# Altium schematic sheet Sch-QWA17_V7_ImageSensor.SchDoc(Sch-QWA17_V7_ImageSensor)
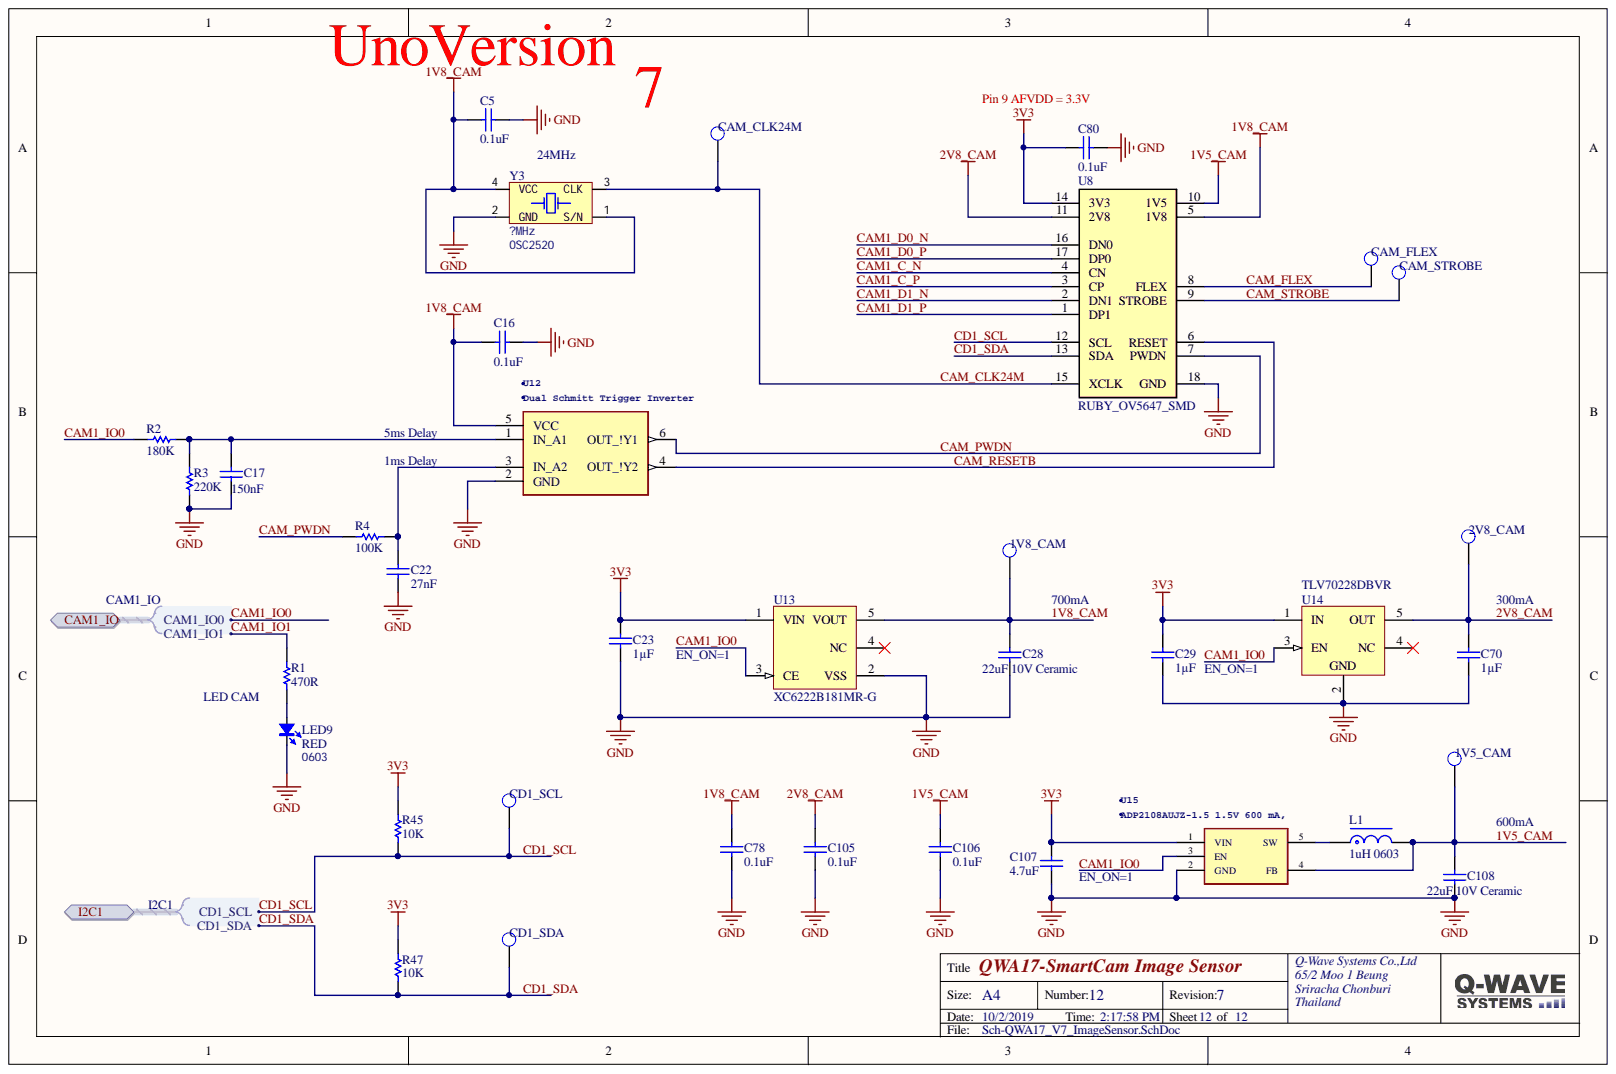
[diagram p0: Sch-QWA17_V7_ImageSensor.SchDoc]

## Parts
1V5_CAM  1V5_CAM  [TEST_POINT]
1V8_CAM  1V8_CAM  [TEST_POINT]
2V8_CAM  2V8_CAM  [TEST_POINT]
C5  0.1uF  [CAP_0603]
C16  0.1uF  [CAP_0603]
C17  150nF  [CAP_0603]
C22  27nF  [CAP_0603]
C23  1µF  [CAP_0603]
C28  22uF 10V Ceramic  [CAP_0805]
C29  1µF  [CAP_0603]
C70  1µF  [CAP_0603]
C78  0.1uF  [CAP_0603]
C80  0.1uF  [CAP_0603]
C105  0.1uF  [CAP_0603]
C106  0.1uF  [CAP_0603]
C107  4.7uF  [6-0805_N]
C108  22uF 10V Ceramic  [CAP_0805]
CAM_CLK24M  CAM_CLK24M  [TEST_POINT]
CAM_FLEX  CAM_FLEX  [TEST_POINT]
CAM_STROBE  CAM_STROBE  [TEST_POINT]
CD1_SCL  CD1_SCL  [TEST_POINT]
CD1_SDA  CD1_SDA  [TEST_POINT]
L1  1uH 0603  [L 0603]
LED9  RED  Type: 0603  [LED_0603_RED]
R1  470R  [RES_0603]
R2  180K  [RES_0603]
R3  220K  [RES_0603]
R4  100K  [RES_0603]
R45  10K  [RES_0603]
R47  10K  [RES_0603]
U8  RUBY_OV5647_SMD  [RUBY_OV5647_SMD]
U12  Dual Schmitt Trigger Inverter  [SOT95P275X110-6N]
U13  XC6222B181MR-G  [TORX-SOT-25-5_L]
U14  TLV70228DBVR  [DBV0005A_M]
U15  ADP2108AUJZ-1.5 1.5V 600 mA,  [SOT95P280X100-5N]
Y3  value ?MHz  Type: OSC2520  [XT_2520_4]  (hidden comment: FJ2400002)

## Ports
CAM1_IO, CAM1_IO.CAM1_IO0, CAM1_IO.CAM1_IO1, I2C1, I2C1.CD1_SCL, I2C1.CD1_SDA

## Nets
3V3: C23.2 C29.2 C80.2 C107.2 R45.1 R47.1 U8.14(3V3) U13.1(VIN) U14.1(IN) U15.1(VIN)
GND: C5.1 C16.1 C17.1 C22.1 C23.1 C28.2 C29.1 C70.1 C78.1 C80.1 C105.2 C106.1 C107.1 C108.2 LED9.2 R3.2 U8.18(GND) U12.2(GND) U13.2(VSS) U14.2 U15.2(GND) Y3.2(GND)
1V5_CAM: 1V5_CAM.1 C106.2 C108.1 L1.2 U8.10(1V5) U15.4(FB)
1V8_CAM: 1V8_CAM.1 C5.2 C16.2 C28.1 C78.2 U8.5(1V8) U12.5(VCC) U13.5(VOUT) Y3.1(S/N) Y3.4(VCC)
2V8_CAM: 2V8_CAM.1 C70.2 C105.1 U8.11(2V8) U14.5(OUT)
CAM1_C_N: U8.4(CN)
CAM1_C_P: U8.3(CP)
CAM1_D0_N: U8.16(DN0)
CAM1_D0_P: U8.17(DP0)
CAM1_D1_N: U8.2(DN1)
CAM1_D1_P: U8.1(DP1)
CAM1_IO0: R2.1 U13.3(CE) U14.3(EN) U15.3(EN) ; port CAM1_IO
CAM1_IO1: R1.2 ; port CAM1_IO
CAM_CLK24M: CAM_CLK24M.1 U8.15(XCLK) Y3.3(CLK)
CAM_FLEX: CAM_FLEX.1 U8.8(FLEX)
CAM_PWDN: R4.1 U8.7(PWDN) U12.6(OUT_!Y1)
CAM_RESETB: U8.6(RESET) U12.4(OUT_!Y2)
CAM_STROBE: CAM_STROBE.1 U8.9(STROBE)
CD1_SCL: CD1_SCL.1 R45.2 U8.12(SCL) ; port I2C1
CD1_SDA: CD1_SDA.1 R47.2 U8.13(SDA) ; port I2C1
NetC17_2: C17.2 R2.2 R3.1 U12.1(IN_A1)
NetC22_2: C22.2 R4.2 U12.3(IN_A2)
NetL1_1: L1.1 U15.5(SW)
NetLED9_1: LED9.1 R1.1

Not connected: U13 4(NC); U14 4(NC)

## Library data of the parts
1V5_CAM 1V8_CAM 2V8_CAM CAM_CLK24M CAM_FLEX CAM_STROBE = TEST_POINT: Test Point; Component Kind: Standard; Library Name: Misc.SCHLIB
C5 C16 C78 C80 C105 C106 = Cap Semi: 0.1µF ±5% 50V Ceramic Capacitor X7R 0603; MFG: KEMET; MFG P/N: C0603C104J5RACTU; Component Kind: Standard; Library Name: Miscellaneous Devices.IntLib; Simulation: CAP
C17 = Cap Semi: 150nF 16VCeramic Capacitor X7R 0603; MFG: KEMET; MFG P/N: C0603C104J5RACTU; Component Kind: Standard; Library Name: Miscellaneous Devices.IntLib; Simulation: CAP
C22 = Cap Semi: 27nF 16VCeramic Capacitor X7R 0603; MFG: KEMET; MFG P/N: C0603C104J5RACTU; Component Kind: Standard; Library Name: Miscellaneous Devices.IntLib; Simulation: CAP
C23 C29 C70 = Cap Semi: 1µF ±5% 50V Ceramic Capacitor X7R 0603; MFG: KEMET; MFG P/N: C0603C104J5RACTU; Component Kind: Standard; Library Name: Miscellaneous Devices.IntLib; Simulation: CAP
C28 C108 = Cap: MFG: KEMET; MFG P/N: C0603C475K8PACTU; Component Kind: Standard; Description: 22uF 10V Ceramic; Library Name: Miscellaneous Devices.IntLib; Simulation: CAP
C107 = Cap: Capacitor; Part Number: GRM188R61A475KE15D; ClassName: uSD_slot; Component Kind: Standard; LatestRevisionDate: 17-Jul-2002; LatestRevisionNote: Re-released for DXP Platform.; Library Name: Miscellaneous Devices.IntLib; PackageDescription: Radial Cap, Thru-Hole; 2 Leads; 0.3 in Pin Spacing; PackageReference: RAD-0.3; Published: 8-Jun-2000; Simulation: CAP; Supplier: LCSC; Value: 4.7uF; Publisher: Altium Limited
CD1_SCL CD1_SDA = TEST_POINT: Component Kind: Standard; Library Name: Misc.SCHLIB
  CD1_SCL: Description: CD1_SCL
  CD1_SDA: Description: CD1_SDA
L1 = L: BOM名称: 小功率贴片电感; 版权归属: 源创客; Component Kind: Standard; Library Name: R L C Common.SchLib; 学习资源: www.ooocke.com
LED9 = LED_0603: LED; Value: color?; Component Kind: Standard; Library Name: SCH_Active.SchLib
R1 = Res Semi: 470R Resistor 0603; Component Kind: Standard; Library Name: SCH_Passive.SchLib; Simulation: RES
R2-R4 R45 R47 = Res Semi: Resistor 0603; Component Kind: Standard; Library Name: Miscellaneous Devices.IntLib; Simulation: RES
U12 = NL27WZ14DTT1G: Manufacturer_Name: ON Semiconductor; GateName_1: G$1; Component Kind: Standard; Description: NL27WZ14DTT1G; DeviceSetName: NL27WZ14DTT1G; Height: 1.1mm; Library Name: NL27WZ14DTT1G.IntLib; LibraryName: NL27WZ14DTT1G; Manufacturer_Part_Number: NL27WZ14DTT1G; Mouser_Part_Number: 863-NL27WZ14DTT1G; Mouser_Price-Stock: https://www.mouser.com/Search/Refine.aspx?Keyword=863-NL27WZ14DTT1G; SymbolName_1: NL27WZ14DTT1G
U13 = CMP-0659-00001-1: High Speed LDO Regulator, 700 mA, 1.8V; Manufacturer: Torex; Accuracy: +/- 1% (Vout>2.0 V) +/- 0.02 V (Vout<=2.0 V); Dropout Voltage: 120 mV @Iout=300 mA (Vout=3.0 V); Low Power Consumption: 100 uA; Operating Voltage Range: 1.7 to 6 V; Out Current (Max): 700 mA; Packing: Tape and Reel; Component Kind: Standard; ComponentLink1Description: Manufacturer URL; ComponentLink1URL: http://www.torex.co.jp/; ComponentLink2Description: Datasheet; ComponentLink2URL: http://www.torex.co.jp/english/products/voltage_regulators/data/XC6222.pdf; DatasheetVersion: Dec-13; Library Name: Altium Content Vault; Mounting Technology: Surface Mount; Operating Ambient Temperature: -40 to 85 degC; Output Voltage: 1.8V; PackageDescription: 5-Pin Small Out-Line Transistor, Body 2.9 x 1.6 mm, Pitch 0.95 mm; PackageReference: MR-G-5; PartNumber: XC6222B181MR-G; RoHS: TRUE
U14 = CMP-0394-00508-2: Single Output LDO, 300 mA, Fixed 2.8 V Output, 2 to 5.5 V Input, with Low IQ, 5-pin SOT-23 (DBV), -40 to 125 degC, Green (RoHS & no Sb/Br); Manufacturer: Texas Instruments; Accuracy(%): 2; Fixed Output Options(V): 2.8; Iout(Max)(A): 0.3; Iq(Typ)(mA): 0.03; SubFamily: Single Channel LDO; Vdo(Typ)(mV): 220; Vin(Max)(V): 5.5; Code_JEDEC: MO-178; Component Kind: Standard; ComponentLink1Description: Manufacturer URL; ComponentLink1URL: http://www.ti.com/; ComponentLink2Description: Datasheet; ComponentLink2URL: http://www.ti.com/general/docs/lit/getliterature.tsp?genericPartNumber=TLV70228&fileType=pdf; ComponentLink3Description: Package Specification; ComponentLink3URL: http://www.ti.com/litv/pdf/mpds018i; DatasheetVersion: SLVSAG6B; Eco-Plan: Green (RoHS & no Sb/Br); Library Name: Altium Content Vault; Mounting Technology: Surface Mount; PackageDescription: 5-Pin Plastic Small Outline, Body 1.60 Ãƒâ€” 2.90 mm, Pitch 0.95 mm; PackageReference: DBV0005A; PackageVersion: 4073253-4/K; PartNumber: TLV70228DBVR; Vin(Min)(V): 2; Vout Adj(Max)(V): 2.8; Vout Adj(Min)(V): 2.8
U15 = ADP2108AUJZ-1.5-R7: Manufacturer_Name: Analog Devices; GateName_1: G$1; Component Kind: Standard; DeviceSetName: ADP2108AUJZ-1.5-R7; Height: mm; Library Name: ADP2108AUJZ-1.IntLib; LibraryName: ADP2108AUJZ-1.5-R7; Manufacturer_Part_Number: ADP2108AUJZ-1.5-R7; Mouser_Part_Number: 584-ADP2108AUJZ1.5R7; Mouser_Price-Stock: https://www.mouser.com/Search/Refine.aspx?Keyword=584-ADP2108AUJZ1.5R7; SymbolName_1: ADP2108AUJZ-1.5-R7
Y3 = OSC_2520_4P: Crystal Oscillator 24MHz; Component Kind: Standard; Library Name: SCH_Oscillator.SchLib
U8 = RUBY_OV5647_SMD: Component Kind: Standard; Description: RUBY_OV5647_SMD; Library Name: QwaveLib.SchLib
